# Altium schematic sheet Power.SchDoc(Power)
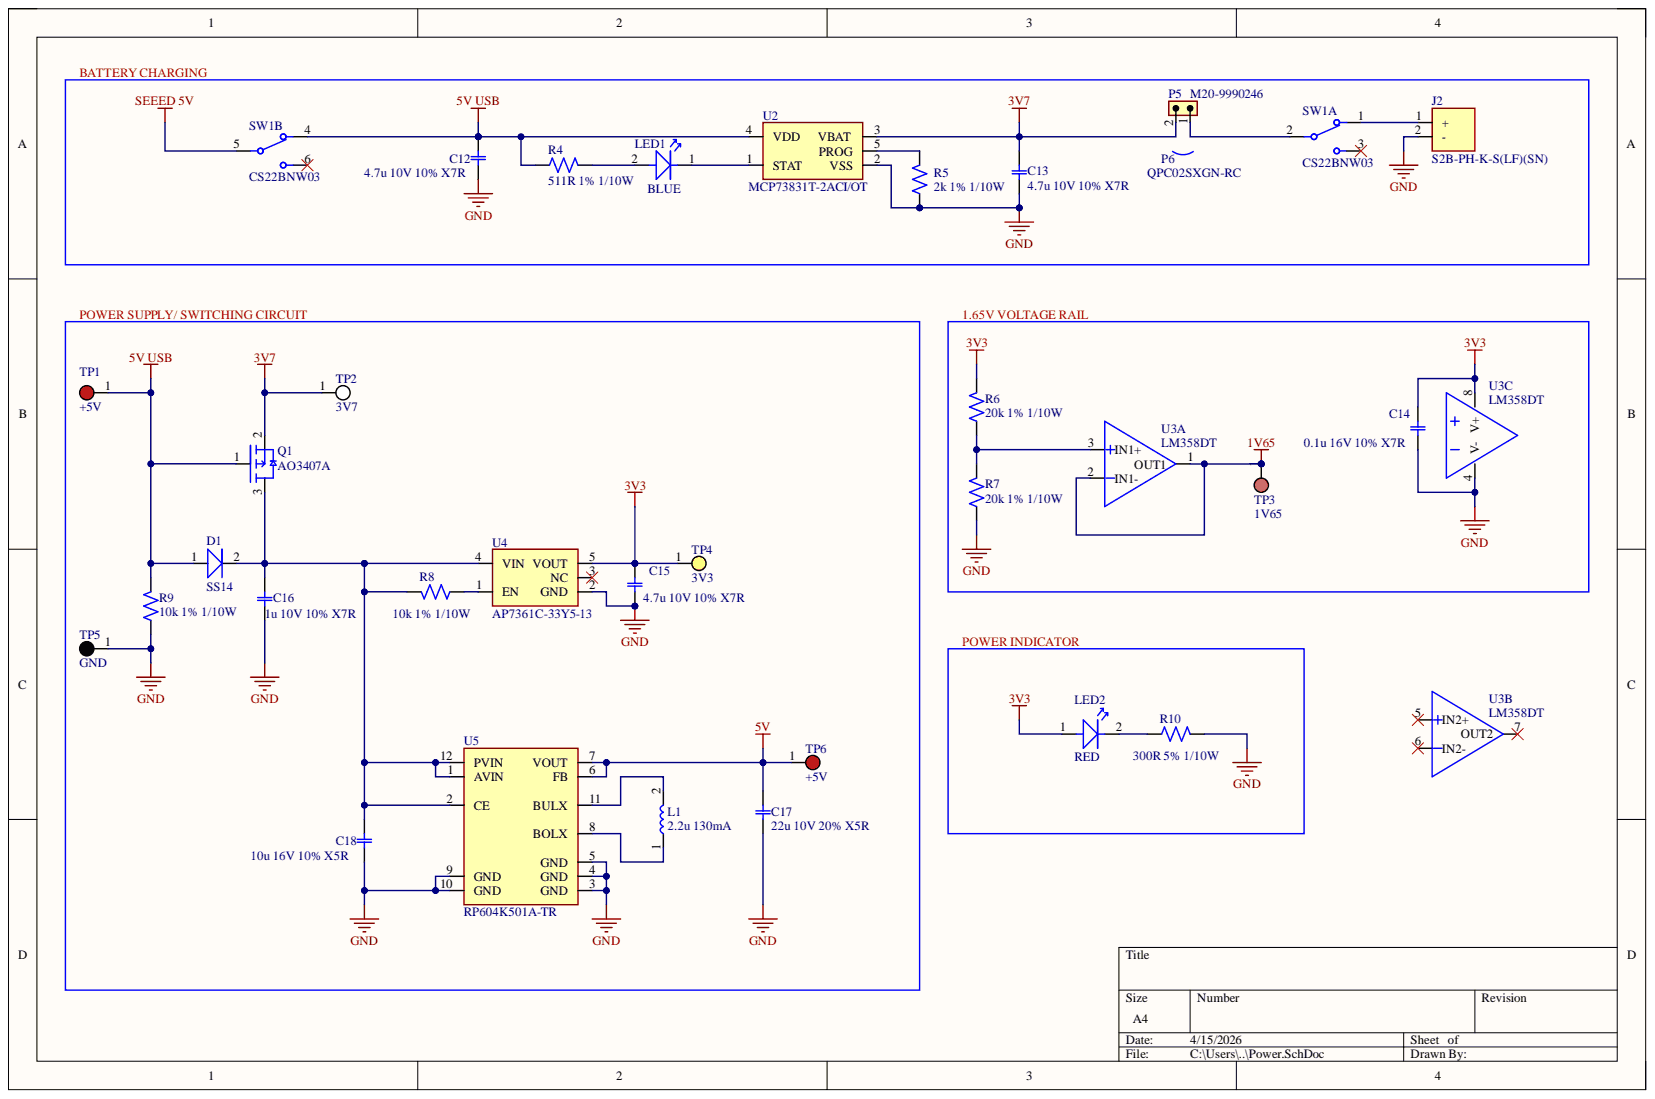
[diagram p0: Power.SchDoc]

## Parts
C12  4.7u 10V 10% X7R  [0603-C]
C13  4.7u 10V 10% X7R  [0603-C]
C14  0.1u 16V 10% X7R  Dielectric: X7R  [0603-C]
C15  4.7u 10V 10% X7R  [0603-C]
C16  1u 10V 10% X7R  Voltage Rating: 10V  [0603-C]
C17  22u 10V 20% X5R  Voltage Rating: 10V  [0603-C]
C18  10u 16V 10% X5R  Dielectric: X5R  [0805-C]
D1  SS14  [SS14]
J2  S2B-PH-K-S(LF)(SN)  [S2B-PH-K-S(LF)(SN)]
L1  2.2u 130mA  [0603 - L]
LED1  BLUE  [1411 - LED]
LED2  RED  [LTST-C190KRKT]
P5  M20-9990246  [M20-9990246]
P6  QPC02SXGN-RC  (mechanical)
Q1  AO3407A  [AO3407A]
R4  511R 1% 1/10W  Tolerance: 1%  [0603-R]
R5  2k 1% 1/10W  Tolerance: 1%  [0603-R]
R6  20k 1% 1/10W  Resistance Tolerance: 1%  [0603-R]
R7  20k 1% 1/10W  Resistance Tolerance: 1%  [0603-R]
R8  10k 1% 1/10W  Resistance Tolerance: 1%  [0603-R]
R9  10k 1% 1/10W  Resistance Tolerance: 1%  [0603-R]
R10  300R 5% 1/10W  Tolerance: 5%  [0603-R]
SW1  CS22BNW03  [CS22BNW03]  (parts A,B)
TP1  +5V  [TEST POINT]
TP2  3V7  [TEST POINT]
TP3  1V65  [TEST POINT]
TP4  3V3  [TEST POINT]
TP5  GND  [TEST POINT]
TP6  +5V  [TEST POINT]
U2  MCP73831T-2ACI/OT  [MCP73831T-2ACI/OT]
U3  LM358DT  [LM358DT]  (parts A,B,C)
U4  AP7361C-33Y5-13  [AP7361C-33Y5-13]
U5  RP604K501A-TR  [RP604K501A-TR]

## Nets
1V65: TP3.1 U3.1(OUT1) U3.2(IN1-)
3V3: C14.1 C15.1 LED2.1 R6.1 TP4.1 U3.8(V+) U4.5(VOUT)
3V7: C13.1 P5.2 Q1.2 TP2.1 U2.3(VBAT)
5V: C17.1 TP6.1 U5.6(FB) U5.7(VOUT)
5V USB: C12.1 D1.1 Q1.1 R4.2 R9.1 SW1.4 TP1.1 U2.4(VDD)
GND: C12.2 C13.2 C14.2 C15.2 C16.2 C17.2 C18.2 J2.2 R5.2 R7.2 R9.2 R10.1 TP5.1 U2.2(VSS) U3.4(V-) U4.2(GND) U5.3(GND) U5.4(GND) U5.5(GND) U5.9(GND) U5.10(GND)
SEEED 5V: SW1.5
NetC16_1: C16.1 C18.1 D1.2 Q1.3 R8.1 U4.4(VIN) U5.1(AVIN) U5.2(CE) U5.12(PVIN)
NetJ2_1: J2.1 SW1.1
NetL1_1: L1.1 U5.8(BOLX)
NetL1_2: L1.2 U5.11(BULX)
NetLED1_1: LED1.1 U2.1(STAT)
NetLED1_2: LED1.2 R4.1
NetLED2_2: LED2.2 R10.2
NetP5_1: P5.1 SW1.2
NetR5_1: R5.1 U2.5(PROG)
NetR6_2: R6.2 R7.1 U3.3(IN1+)
NetR8_2: R8.2 U4.1(EN)

Not connected: SW1 3 6; U3 5(IN2+) 6(IN2-) 7(OUT2); U4 3(NC)
